# Altium schematic sheet CW553_LCD_Joystick.SchDoc
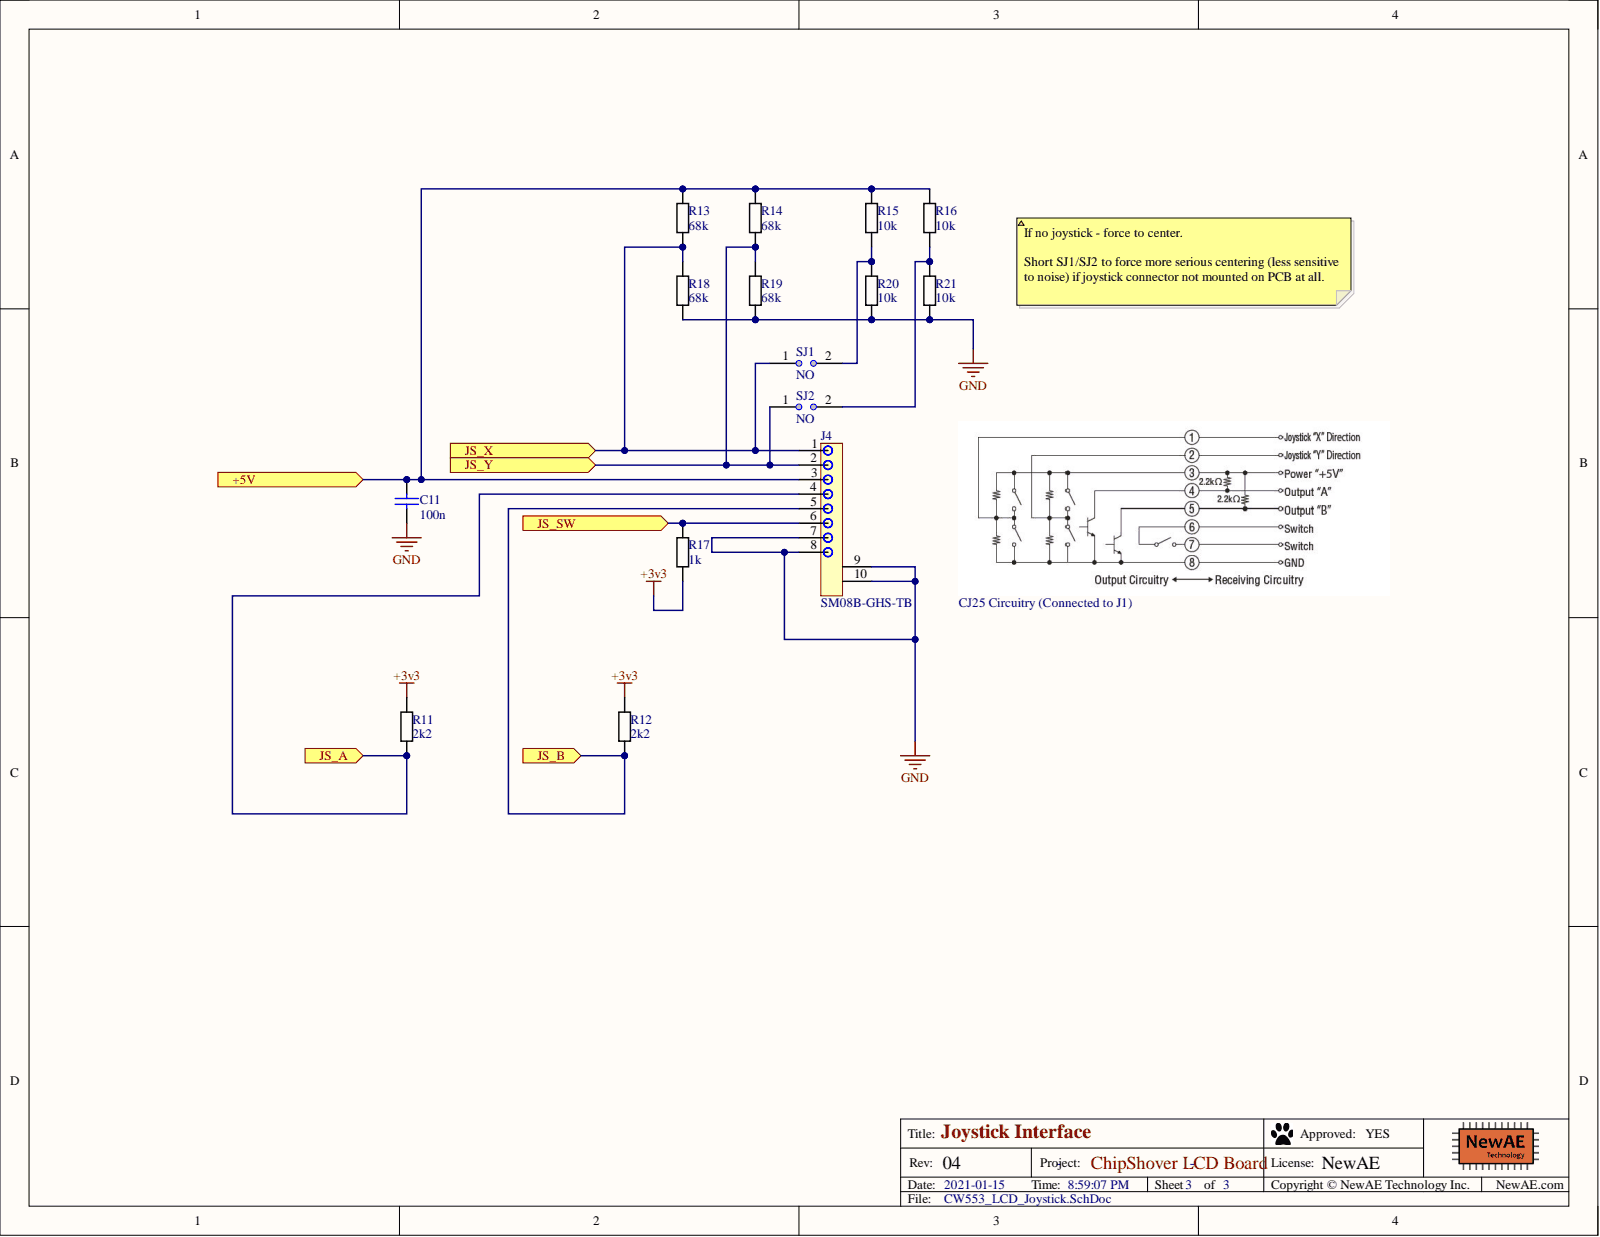
[diagram p0: CW553_LCD_Joystick.SchDoc]

## Parts
C11  100n  [0603]
J4  SM08B-GHS-TB  [SM08B-GHS-TB]
R11  2k2  [RESC1608X55N]
R12  2k2  [RESC1608X55N]
R13  68k  [0603]
R14  68k  [0603]
R15  10k  [0603]
R16  10k  [0603]
R17  1k  [0603]
R18  68k  [0603]
R19  68k  [0603]
R20  10k  [0603]
R21  10k  [0603]
SJ1  NO  [SJ_2S_NO]  (no BOM)
SJ2  NO  [SJ_2S_NO]  (no BOM)

## Ports
+5V, JS_A, JS_B, JS_SW, JS_X, JS_Y

## Nets
+3v3: R11.2 R12.2 R17.2
GND: C11.2 J4.7 J4.8 J4.9 J4.10 R18.1 R19.1 R20.2 R21.2
+5V: C11.1 J4.3 R13.2 R14.2 R15.1 R16.1 ; port +5V
JS_A: J4.4 R11.1 ; port JS_A
JS_B: J4.5 R12.1 ; port JS_B
JS_SW: J4.6 R17.1 ; port JS_SW
JS_X: J4.1 R13.1 R18.2 SJ1.1 ; port JS_X
JS_Y: J4.2 R14.1 R19.2 SJ2.1 ; port JS_Y
NetR15_2: R15.2 R20.1 SJ1.2
NetR16_2: R16.2 R21.1 SJ2.2

## Library data of the parts
C11 = CCHIP-100n-0603: 100n, 0603; Manufacture 1: Kemet; Manufacture Part Number 1: C0603C104K5RACTU; Case-Metric: 1608; Component Group: Capacitor; Database Table Name: main; Rated Voltage: 50VDC; Case-EIA: 0603; Component Kind: Standard; Component Type: Standard; Library Name: NewAE_AltiumLib.DBLib; Mounting Technology: SMT; Part Number: CCHIP-100n-0603; Pin Count: 2; Supplier 1: Digi-Key; Supplier Part Number 1: 399-5089-2-ND; Value: 100n
J4 = SM08B-GHS-TB: Connector, 8-pin RA, SMD; Manufacture 1: JST; Database Table Name: main; PP Material Stack: 0; Component Group: Connector; Component Kind: Standard; Component Type: Standard; Library Name: NewAE_AltiumLib.DBLib; Manufacture Part Number 1: SM08B-GHS-TB; Part Number: SM08B-GHS-TB; Pin Count: 10; PP Rotation: 0; Supplier 1: Digi-Key; Supplier Part Number 1: 455-1570-1-ND
R11 R12 = RCHIP-2K2-0603: 2k2 res, 0603, 1%, 1/10W; Manufacture 1: Yageo; Manufacture Part Number 1: RC0603FR-072K2L; Case-EIA: 0603; Case-Metric: 1608; Component Group: Resistor; Rated Power: 0.1W; Rated Voltage: 75VDC; 0_TEMP: RESC1608X55N; Component Kind: Standard; Component Type: Standard; Library Name: CW550_MAIN.SCHLIB; Mounting Technology: SMT; Part Number: RCHIP-2K2-0603; Pin Count: 2; Supplier 1: Digi-Key; Supplier Part Number 1: 311-2.20KHRCT-ND; Temperature Range: -55C to 155C; Tolerance: 1%; Value: 2k2
R13 R14 R18 R19 = RCHIP-68K-0603: 68k res, 0603, 1%, 1/10W; Manufacture 1: Yageo; Manufacture Part Number 1: RC0603FR-0768KL; Case-Metric: 1608; Component Group: Resistor; Database Table Name: main; Rated Power: 0.1W; Rated Voltage: 75VDC; Case-EIA: 0603; Component Kind: Standard; Component Type: Standard; Library Name: NewAE_AltiumLib.DBLib; Mounting Technology: SMT; Part Number: RCHIP-68K-0603; Pin Count: 2; Supplier 1: Digi-Key; Supplier Part Number 1: 311-68.0KHRCT-ND; Temperature Range: -55C to 155C; Tolerance: 1%; Value: 68k
R15 R16 R20 R21 = RCHIP-10K-0603: 10k res, 0603, 1%, 1/10W; Manufacture 1: Yageo; Manufacture Part Number 1: RC0603FR-0710KL; Case-Metric: 1608; Component Group: Resistor; Database Table Name: main; Rated Power: 0.1W; Rated Voltage: 75VDC; Case-EIA: 0603; Component Kind: Standard; Component Type: Standard; Library Name: NewAE_AltiumLib.DBLib; Mounting Technology: SMT; Part Number: RCHIP-10K-0603; Pin Count: 2; Supplier 1: Digi-Key; Supplier Part Number 1: 311-10.0KHRCT-ND; Temperature Range: -55C to 155C; Tolerance: 1%; Value: 10k
R17 = RCHIP-1K-0603: 1k res, 0603, 1%, 1/10W; Manufacture 1: Yageo; Manufacture Part Number 1: RC0603FR-071KL; Case-Metric: 1608; Component Group: Resistor; Database Table Name: main; Rated Power: 0.1W; Rated Voltage: 75VDC; Case-EIA: 0603; Component Kind: Standard; Component Type: Standard; Library Name: NewAE_AltiumLib.DBLib; Mounting Technology: SMT; Part Number: RCHIP-1K-0603; Pin Count: 2; Supplier 1: Digi-Key; Supplier Part Number 1: 311-1.00KHRCT-ND; Temperature Range: -55C to 155C; Tolerance: 1%; Value: 1k
SJ1 SJ2 = SJ_2S_NO: Solder Jumper, NO; Component Group: PCB Feature; Database Table Name: main; Component Type: Standard (No BOM); Library Name: NewAE_AltiumLib.DBLib; Mounting Technology: COPPER; Part Number: SJ_2S_NO; Pin Count: 2
